# Altium schematic sheet [18]-WIFI_MODULE.SchDoc([18]-WIFI_MODULE)
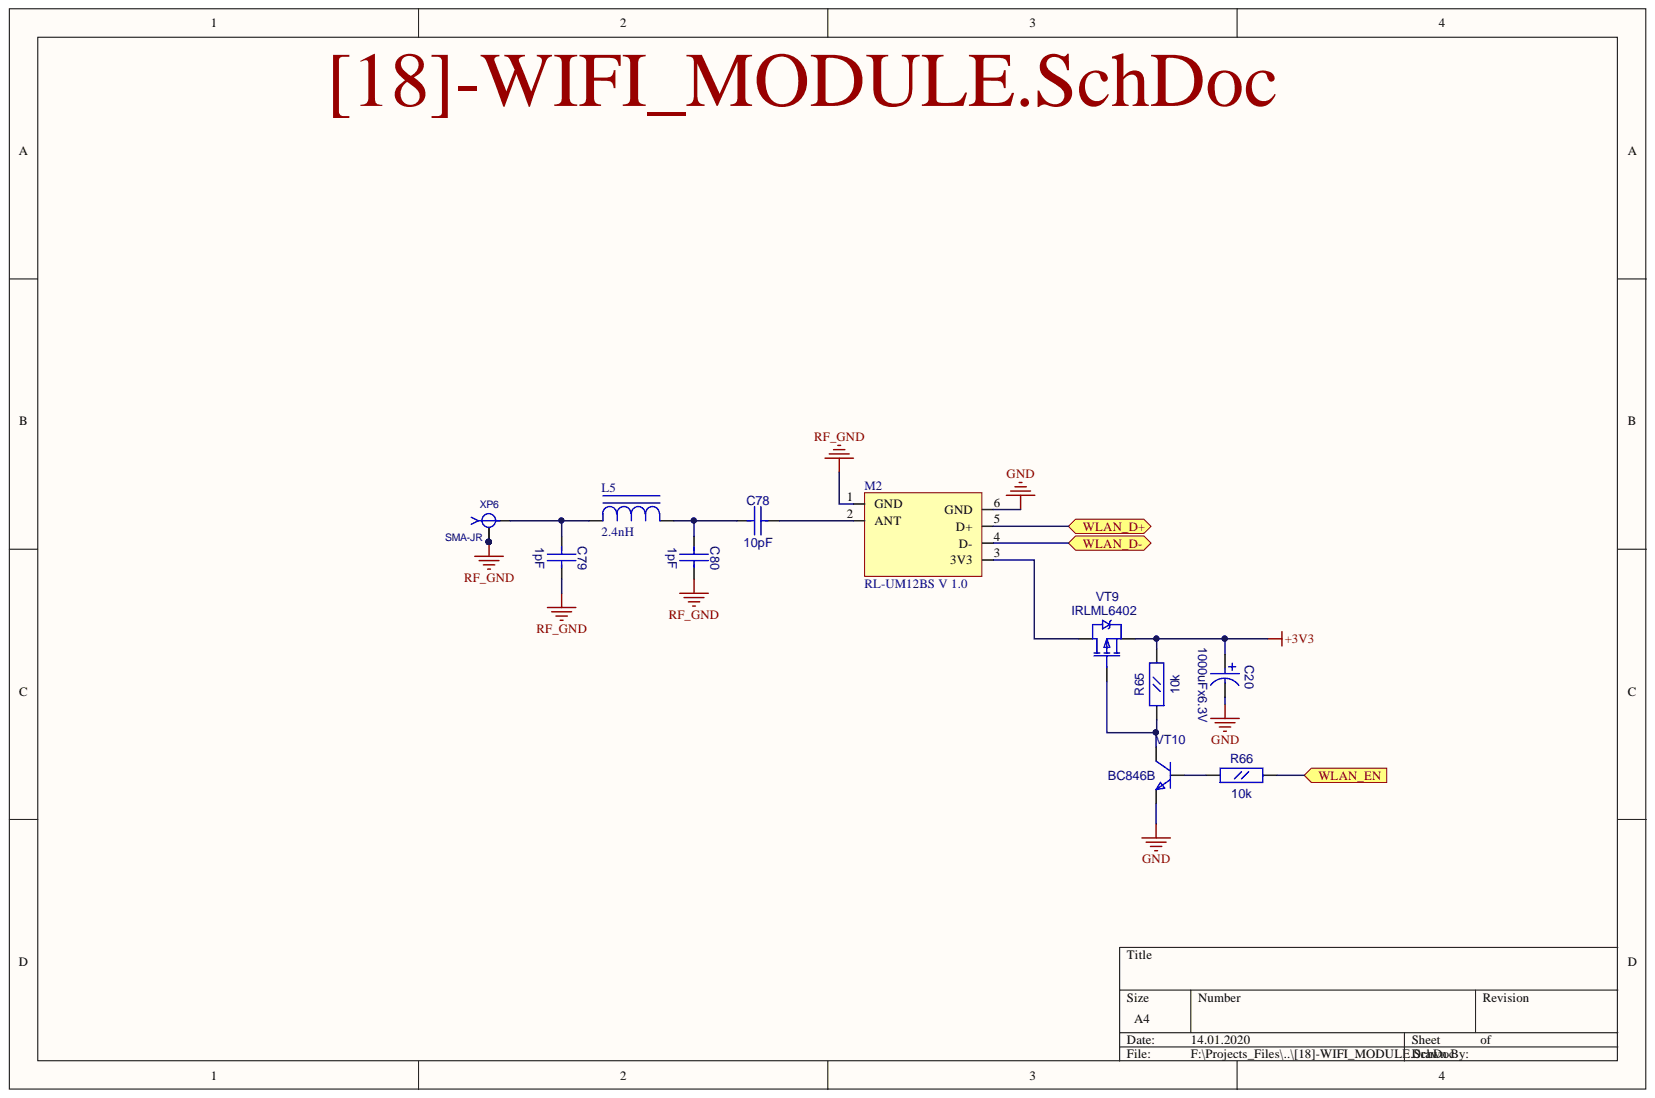
[diagram p0: [18]-WIFI_MODULE.SchDoc]

## Parts
C20  1000uFx6.3V  [CC8X3.5]
C78  10pF  [CC0603]
C79  1pF  [CC0603]
C80  1pF  [CC0603]
L5  2.4nH  [L0402]
M2  RL-UM12BS V 1.0  [RTL8188_MODULE]
R65  10k  [RC0603]
R66  10k  [RC0603]
VT9  IRLML6402  [SOT-23(123)]
VT10  BC846B  [SOT-23(123)]
XP6  SMA-JR  [SMA-JR]

## Ports
WLAN_D+, WLAN_D-, WLAN_EN

## Nets
+3V3: C20.1 R65.2 VT9.2
GND: C20.2 M2.6(GND) VT10.2
RF_GND: C79.2 C80.2 M2.1(GND) XP6.2 XP6.3 XP6.4 XP6.5
WLAN_EN: R66.2 ; port WLAN_EN
NetC78_1: C78.1 C80.1 L5.2
NetC78_2: C78.2 M2.2(ANT)
NetC79_1: C79.1 L5.1 XP6.1
NetM2_3: M2.3(3V3) VT9.3
NetM2_4: M2.4(D-)
NetM2_5: M2.5(D+)
NetR65_1: R65.1 VT9.1 VT10.3
NetR66_1: R66.1 VT10.1

## Library data of the parts
C20 = CAP_8mm: Radial capacitor; Value: 1000uFx6.3V; Component Kind: Standard; Library Name: fizik_lib (1).SchLib
C78-C80 = CC0603: Description: CC0603; Component Kind: Standard; Library Name: gprs_modem_2.SchLib
  C78: Value: 10pF
  C79 C80: Value: 1pF
L5 = L0402: Value: 2.4nH; Description: L0402; Component Kind: Standard; Library Name: gprs_modem_2.SchLib
M2 = RTL8188_MODULE: Value: RL-UM12BS V 1.0; Component Kind: Standard; Library Name: fizik_lib.SchLib
R65 R66 = RC0603: Value: 10k; Description: RC0603; Component Kind: Standard; Library Name: gprs_modem_2.SchLib
VT9 = IRLML6402: HEXFET Power MOSFET; OriginalName: IRLML5103; ComponentField7: Published 8-Jun-2000; Value: IRLML6402; Component Kind: Standard; Library Name: gprs_modem_2.SchLib
VT10 = BC846BLT1: ComponentField7: Published 29-Sep-2000; Value: BC846B; Simulation: BC846BLT1; OriginalName: BC846BLT1; Description: BC846BLT1; Component Kind: Standard; Library Name: gprs_modem_2.SchLib
XP6 = SMA-JR: Value: SMA-JR; Description: SMA-JR; Component Kind: Standard; Library Name: fizik_lib.SchLib
